# Altium schematic sheet FirstProject_Sheet1.SchDoc
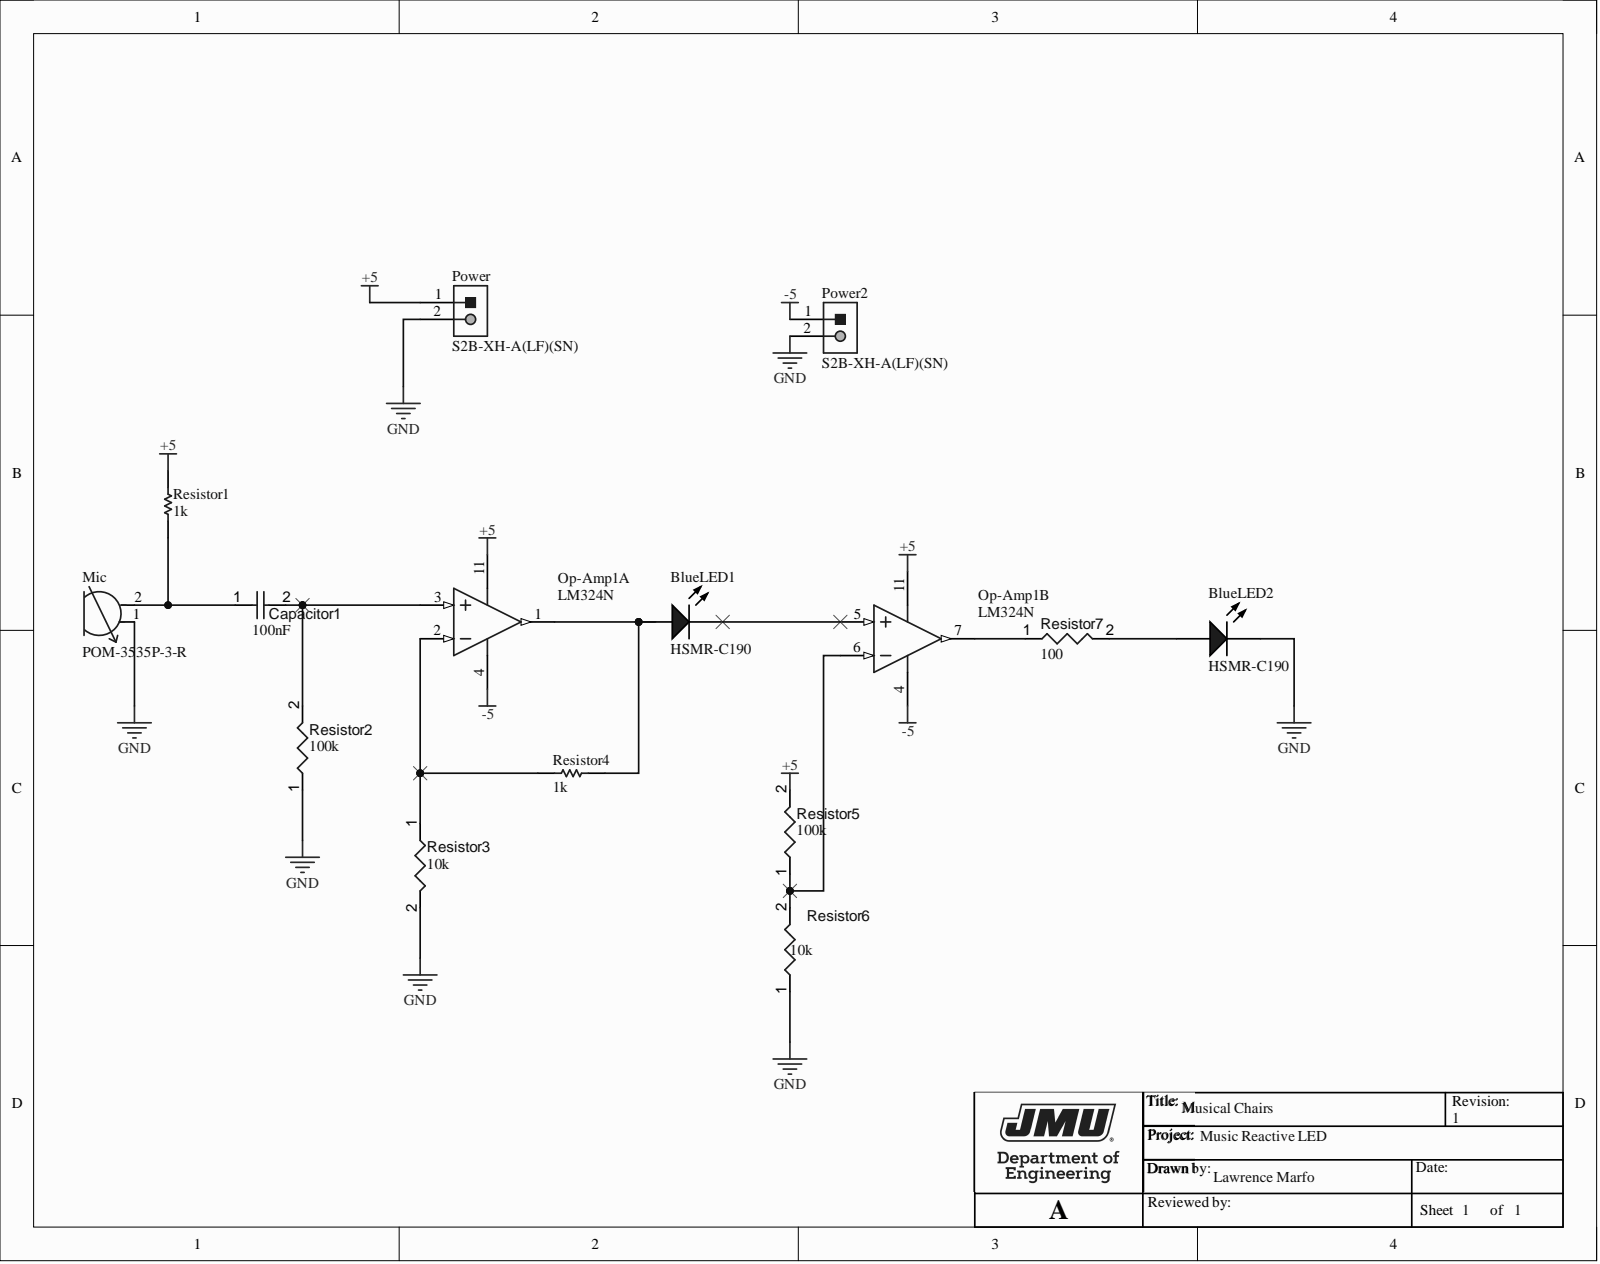
[diagram p0: FirstProject_Sheet1.SchDoc]

## Parts
BlueLED1  HSMR-C190  [AVAG-HSMX-C190_V]
BlueLED2  HSMR-C190  [AVAG-HSMX-C190_V]
Capacitor1  value 100nF  [CAPC1005X56X25LL10T15]  (hidden comment: Capacitor 0.1 uF +/- 5% 16 V 0402)
Mic  POM-3535P-3-R  [FP-POM-3535P-3-R-MFG]
Op-Amp1  LM324N  [N0014A]  (parts A,B)
Power  S2B-XH-A(LF)(SN)  [JST-S2B-XH-A(LF)(SN)_V]
Power2  S2B-XH-A(LF)(SN)  [JST-S2B-XH-A(LF)(SN)_V]
Resistor1  value 1k  [RESC1005X40X25ML05T05]  (hidden comment: Resistor 1k +/-1% 0402 100 mW)
Resistor2  value 100k  [RESC1608X55X25ML10T15]  (hidden comment: Resistor 100k +/-1% 0603 100 mW)
Resistor3  value 10k  [RESC1608X55X25ML10T15]  (hidden comment: Resistor 100k +/-1% 0603 100 mW)
Resistor4  value 1k  [RESC1005X40X25ML05T05]  (hidden comment: Resistor 1k +/-1% 0402 100 mW)
Resistor5  value 100k  [RESC1608X55X25ML10T15]  (hidden comment: Resistor 100k +/-1% 0603 100 mW)
Resistor6  value 10k  [RESC1005X40X25ML05T05]  (hidden comment: Resistor 10k +/-1% 0402 63 mW)
Resistor7  value 100  [RESC0402(1005)_L]  (hidden comment: Resistor 100R +/-1 % 0402 63 mW)

## Nets
+5: Op-Amp1.11 Power.1 Resistor1.2 Resistor5.2
-5: Op-Amp1.4 Power2.1
GND: BlueLED2.2 Mic.1 Power.2 Power2.2 Resistor2.1 Resistor3.2 Resistor6.1
NetBlueLED1_1: BlueLED1.1 Op-Amp1.1 Resistor4.1
NetBlueLED1_2: BlueLED1.2 Op-Amp1.5
NetBlueLED2_1: BlueLED2.1 Resistor7.2
NetCapacitor1_1: Capacitor1.1 Mic.2 Resistor1.1
NetCapacitor1_2: Capacitor1.2 Op-Amp1.3 Resistor2.2
NetOp-Amp1_2: Op-Amp1.2 Resistor3.1 Resistor4.2
NetOp-Amp1_6: Op-Amp1.6 Resistor5.1 Resistor6.2
NetOp-Amp1_7: Op-Amp1.7 Resistor7.1

## Library data of the parts
BlueLED1 BlueLED2 = CMP-007-00015-2: LED Uni-Color Blue, 78 mW, 20 mA, -40 to 85 degC, 2-Pin SMD, RoHS, Tape and Reel; Manufacturer: Avago; Forward Current: 20mA; Forward Voltage: 3.4V; Lens Style: Diffused; Luminous Intensity: 55mcd; Power: 78mW; Viewing Angle: 155degrees; Case/Package: 0603; Colour: Blue; Component Kind: Standard; Datasheet URL: http://docs.avagotech.com/docs/AV02-0977EN; Datasheet Version: Apr-10; Illumination Colour: Blue; Manufacturer URL: http://www.avagotech.com/; Max Operating Temperature: 85°C; Min Operating Temperature: -40°C; Mounting Technology: SM; Nominal Vf: 3.4V; Package Description: 2-Pin Surface Mount Device, Body 1.6 x 0.8 mm, Height 0.9 mm; Package Quantity: 4000; Package Reference: HSMX-C190; Packaging: TapeandReel; Pins: 2; RoHS Compliant: True; Library Name: James Madison University
Capacitor1 = CMP-001-00001-6: Chip Capacitor, 0.1 uF, +/- 5%, 16 V, 0402 (1005 Metric); Capacitor Type: Ceramic; Voltage Rating: 16V; Case/Package: 0402; Component Kind: Standard; Max Operating Temperature: 125°C; Min Operating Temperature: -55°C; Mounting Technology: SM; Pins: 2; RoHS Compliant: True; Tolerance: 5%; Library Name: James Madison University
Mic = CMP-231723-000001-1: MIC COND ANALOG OMNI -35DB 6MM D; Direction: Omnidirectional; Impedance: 2.2kR; Operating Supply Current: 500uA; Resistance: 2200R; Shape: Round; Voltage Rating: 2V; Component Kind: Standard; Depth: 3.7mm; Diameter: 6mm; Height: 3.7mm; Library Name: Altium Content Vault; Mount: Through Hole; Output Type: Analog; Package Quantity: 100; Packaging: Bulk; Termination: PC Pins
Op-Amp1 = CMP-0055-00082-3: Low Power Quad Operational Amplifier, 14-pin MDIP; Manufacturer: Texas Instruments; CompType: Amplifiers; PackageTopMarking: NSUZXYYTTE#*LM324N; PowerWise: N; Code_JEDEC: MS-001-AA; Component Kind: Standard; ComponentLink1Description: Datasheet URL; ComponentLink1URL: http://www.ti.com/general/docs/lit/getliterature.tsp?genericPartNumber=LM324&fileType=pdf; Library Name: Altium Content Vault; Package Reference: N0014A; PackageDescription: MDIP, .750 x .250 x.130in, 14 Lead, .100in Pitch; PinCount: 14; RoHS: N
Power Power2 = CMP-002-00032-2: Male Header, Pitch 2.5 mm, 1 x 2 Position, Height 6.1 mm, Tail Length 3.4 mm, -25 to 85 degC, RoHS, Bulk; Manufacturer: JST; Case/Package: S2B-XH-A(LF)(SN); Component Kind: Standard; Connector Type: Header; Datasheet URL: http://www.jst-mfg.com/product/pdf/eng/eXH.pdf; Datasheet Version: May-16; Gender: Male; Manufacturer URL: http://www.jst.com/home2.html; Max Operating Temperature: 85°C; Min Operating Temperature: -25°C; Mounting Technology: TH; Package Description: XH Series Disconnectable Crimp Style Connector, Pitch 2.5 mm, 1 x 2 Position, Height 6.1 mm, Tail Length 3.4 mm; Package Reference: S2B-XH-A(LF)(SN); RoHS Compliant: Unknown; Library Name: James Madison University
Resistor1 Resistor4 = CMP-009-00009-5: Chip Resistor, 1 KOhm, +/- 1%, 0.1 W, -55 to 155 degC, 0402 (1005 Metric); Power: 100mW; Voltage Rating: 50V; Case/Package: 0402; Component Kind: Standard; Max Operating Temperature: 155°C; Min Operating Temperature: -55°C; Mounting Technology: SM; Pins: 2; RoHS Compliant: True; Tolerance: 1%; Library Name: James Madison University
Resistor2 Resistor3 Resistor5 = CMP-009-00023-5: Chip Resistor, 100 KOhm, +/- 1%, 0.1 W, -55 to 155 degC, 0603 (1608 Metric); Power: 100mW; Voltage Rating: 50V; Case/Package: 0603; Component Kind: Standard; Max Operating Temperature: 155°C; Min Operating Temperature: -55°C; Mounting Technology: SM; Pins: 2; RoHS Compliant: True; Tolerance: 1%; Library Name: James Madison University
Resistor6 = CMP-009-00019-5: Chip Resistor, 10 KOhm, +/- 1%, 63 mW, -55 to 155 degC, 0402 (1005 Metric); Power: 63mW; Voltage Rating: 50V; Case/Package: 0402; Component Kind: Standard; Max Operating Temperature: 155°C; Min Operating Temperature: -55°C; Mounting Technology: SM; Pins: 2; RoHS Compliant: True; Tolerance: 1%; Library Name: James Madison University
Resistor7 = CMP-009-00167-3: Chip Resistor, 100 Ohms, +/-1 %, 63 mW, -55 to 155 degC, 0402; Power: 63mW; Tolerance: 1%; Case/Package: 0402; Component Kind: Standard; Max Operating Temperature: 155°C; Min Operating Temperature: -55°C; Pins: 2; Library Name: James Madison University
